# Altium schematic sheet Solar Batter Charger SCH_2.SchDoc("Solar Batter Charger SCH_2")
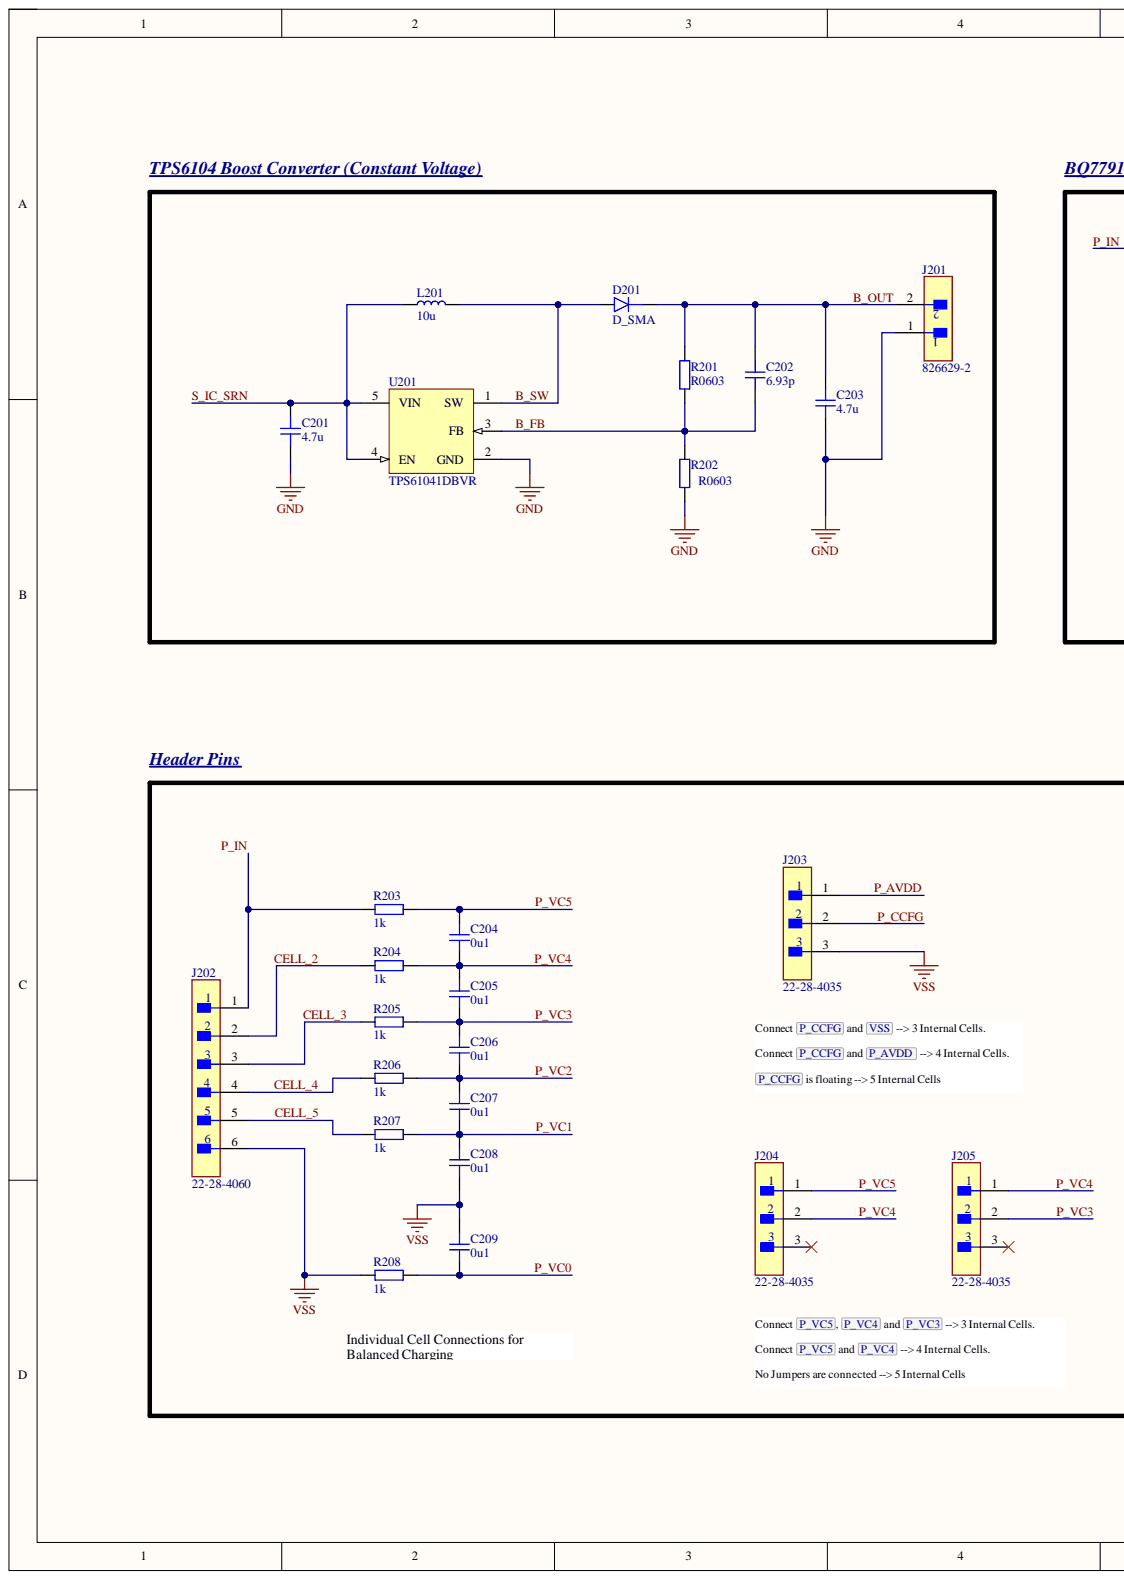
[diagram p0: Solar Batter Charger SCH_2.SchDoc, left]
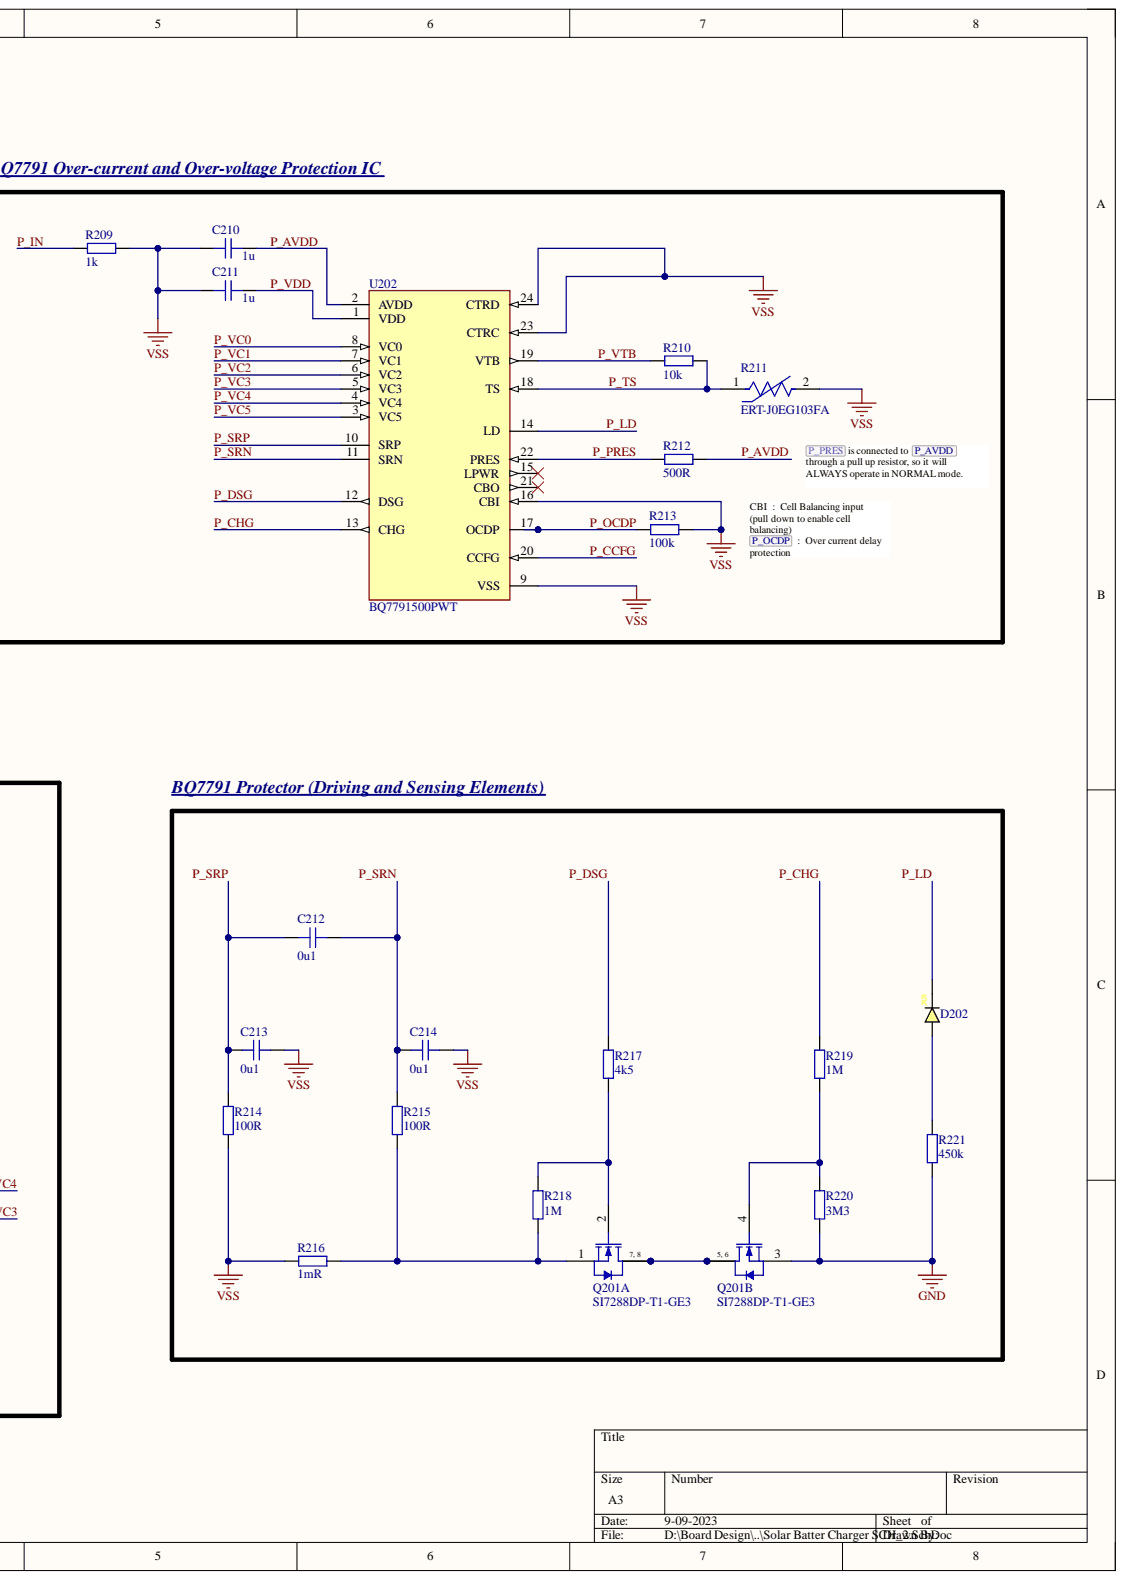
[diagram p1: Solar Batter Charger SCH_2.SchDoc, right]

## Parts
C201  4.7u  [CAP_1210]
C202  6.93p  [CAP_0805]
C203  4.7u  [CAP_1210]
C204  0u1  [CAP_0603]
C205  0u1  [CAP_0603]
C206  0u1  [CAP_0603]
C207  0u1  [CAP_0603]
C208  0u1  [CAP_0603]
C209  0u1  [CAP_0603]
C210  1u  [CAP_0603]
C211  1u  [CAP_0603]
C212  0u1  [CAP_0603]
C213  0u1  [CAP_0603]
C214  0u1  [CAP_0603]
D201  D_SMA  [DO-214AC-2 (SMA)]
D202  YELLOW  [LED_0603_YELLOW]  (value not drawn)
J201  826629-2  [FP-826629-2-MFG]
J202  22-28-4060  [FP-22-28-4060-MFG]
J203  22-28-4035  [FP-22-28-4035-MFG]
J204  22-28-4035  [FP-22-28-4035-MFG]
J205  22-28-4035  [FP-22-28-4035-MFG]
L201  10u  [NRS5020]
Q201  SI7288DP-T1-GE3  [VISH-POWERPAKSO-8_A_V]  (parts A,B)
R201  R0603  [RES_0603]
R202  R0603  [RES_0603]
R203  1k  [RES_0603]
R204  1k  [RES_0603]
R205  1k  [RES_0603]
R206  1k  [RES_0603]
R207  1k  [RES_0603]
R208  1k  [RES_0603]
R209  1k  [RES_0603]
R210  10k  [RES_0402]
R211  ERT-J0EG103FA  [FP-ERTJ0-MFG]
R212  500R  [RES_0402]
R213  100k  [RES_0805]
R214  100R  [RES_0402]
R215  100R  [RES_0402]
R216  1mR  [RES_0603]
R217  4k5  [RES_0805]
R218  1M  [RES_0805]
R219  1M  [RES_0805]
R220  3M3  [RES_0805]
R221  450k  [RES_0805]
U201  TPS61041DBVR  [DBV0005A_M]
U202  BQ7791500PWT  [FP-PW0024A-MFG]

## Nets
GND: C201.1 C203.1 J201.1 Q201.3 R202.1 R220.1 R221.1 U201.2(GND)
VSS: C208.2 C209.1 C210.1 C211.1 C213.2 C214.2 J202.6 J203.3 R208.1 R209.2 R211.2 R213.2 R214.1 R216.2 U202.9(VSS) U202.16(CBI) U202.23(CTRC) U202.24(CTRD)
B_FB: C202.2 R201.1 R202.2 U201.3(FB)
B_OUT: C202.1 C203.2 D201.K J201.2 R201.2
B_SW: D201.A L201.2 U201.1(SW)
CELL_2: J202.2 R204.1
CELL_3: J202.3 R205.1
CELL_4: J202.4 R206.1
CELL_5: J202.5 R207.1
P_AVDD: C210.2 J203.1 R212.2 U202.2(AVDD)
P_CCFG: J203.2 U202.20(CCFG)
P_CHG: R219.2 U202.13(CHG)
P_DSG: R217.2 U202.12(DSG)
P_IN: J202.1 R203.1 R209.1
P_LD: D202.K U202.14(LD)
P_OCDP: R213.1 U202.17(OCDP)
P_PRES: R212.1 U202.22(PRES)
P_SRN: C212.2 C214.1 R215.2 U202.11(SRN)
P_SRP: C212.1 C213.1 R214.2 U202.10(SRP)
P_TS: R210.2 R211.1 U202.18(TS)
P_VC0: C209.2 R208.2 U202.8(VC0)
P_VC1: C207.2 C208.1 R207.2 U202.7(VC1)
P_VC2: C206.2 C207.1 R206.2 U202.6(VC2)
P_VC3: C205.2 C206.1 J205.2 R205.2 U202.5(VC3)
P_VC4: C204.2 C205.1 J204.2 J205.1 R204.2 U202.4(VC4)
P_VC5: C204.1 J204.1 R203.2 U202.3(VC5)
P_VDD: C211.2 U202.1(VDD)
P_VTB: R210.1 U202.19(VTB)
S_IC_SRN: C201.2 L201.1 U201.4(EN) U201.5(VIN)
NetD202_A: D202.A R221.2
NetQ201_1: Q201.1 R215.1 R216.1 R218.1
NetQ201_2: Q201.2 R217.1 R218.2
NetQ201_4: Q201.4 R219.1 R220.2
NetQ201_5: Q201.5 Q201.6 Q201.7 Q201.8

Not connected: J204 3; J205 3; U202 15(LPWR) 21(CBO)

## Library data of the parts
C201 C203 = CAP_1210: Capacitor SMD 1210; Component Kind: Standard; Library Name: Schematic-Lib-PhilsLab.SchLib
C202 = CAP_0805: Capacitor SMD 0805; Component Kind: Standard; Library Name: Schematic-Lib-PhilsLab.SchLib
C204-C214 = CAP_0603: Capacitor SMD 0603; Component Kind: Standard; Library Name: Schematic-Lib-PhilsLab.SchLib
D201 = D_SMA: Diode SMD SMA (DO-214AA); Component Kind: Standard; Library Name: Schematic-Lib-PhilsLab.SchLib
D202 = LED_0603_YELLOW: LED 0603 YELLOW SMD; Component Kind: Standard; Library Name: Schematic-Lib-PhilsLab.SchLib
J201 = CMP-05178-000003-1: CONN HEADER VERT 2POS 2.54MM; Component Kind: Standard; Library Name: Altium Content Vault; RoHS Compliant: Yes
J202 = CMP-04776-000763-1: CONN HEADER VERT 6POS 2.54MM; Connector Type: Male Pin; Contact Resistance: 20mR; Current Rating: 4A; Gender: Male; Max Voltage Rating (AC): 250V; Max Voltage Rating (DC): 250.25kV; Number of Contacts: 6; Color: Black; Component Kind: Standard; Contact Material: Brass; Contact Mating Length: 0.24inch; Contact Plating: Tin; Contact Type: Male Pin; Depth: 2.49mm; Housing Color: Black; Housing Material: Thermoplastic; Length: 15.24mm; Library Name: Altium Content Vault; Max Operating Temperature: 75°C; Min Operating Temperature: 0°C; Mount: Through Hole; Number of Positions: 6; Number of Rows: 1; Orientation: Straight; Package Quantity: 1; Packaging: Bulk; Pitch: 2.54mm; RoHS Compliant: Yes; Series: KK® 42375; Termination: Solder; Voltage Rating: 250V
J203-J205 = CMP-00257-00247547-1: 22-28-4035 BOARD-BOARD Connector HEADER, 3WAY, 1ROW; Series: KK; Pitch Spacing: 2.54mm; No. of Rows: 1;; Manufacturer Lifecycle Status: ACTIVE; NexarPartId: 247547; Connector Type: Male Pin; Contact Resistance: 20mΩ; Current Rating: 4A; Gender: Male; Glow Wire Compliant: Non-compliant; Max Voltage Rating (DC): 250.25kV; Number of Circuits: 3; Plating: Tin; Voltage Rating: 250V; Color: Black; Component Kind: Standard; Contact Material: Brass; Contact Mating Length: 7.493mm; Contact Plating: Gold; Country of Origin: US; Housing Color: Black; Housing Material: Thermoplastic; Lead Free: Lead Free; Library Name: Altium Content Vault; Lifecycle Status: Production; Max Operating Temperature: 75°C; Min Operating Temperature: 0°C; Mount: Through Hole; Number of Contacts: 3; Number of Positions: 3; Number of Rows: 1; Orientation: Vertical; Packaging: Bulk; Pitch: 2.54mm; REACH SVHC: Unknown; RoHS: Compliant; Schedule B: 8536694040; Termination: Through Hole
L201 = NRS5020: NRS5020 SMD Power Inductor Taiyo Yuden; Component Kind: Standard; Manufacturer: Taiyo Yuden; Manufacturer Part Number: NRS5020; Library Name: Schematic-Lib-PhilsLab.SchLib
Q201 = CMP-2000-07062-1: Dual N-Channel (D-S) MOSFET, 40 V, 20 A, -55 to 150 degC, 8-Pin POWERPAKSO-8, RoHS, Tape and Reel; Manufacturer: Vishay; Current - Continuous Drain (Id) @ 25°C: 20A; Drain to Source Voltage (Vdss): 40V; FET Feature: Standard; FET Type: 2N-Channel(Dual); Gate Charge (Qg) @ Vgs: 15nC@10V; Input Capacitance (Ciss) @ Vds: 565pF@20V; Online Catalog: N-ChannelStandardFETs; Other Names: SI7288DP-T1-GE3DKR; PCN Assembly/Origin: MultipleFabracationChanges09/Jul/2014; Power - Max: 15.6W; Rds On (Max) @ Id, Vgs: 19mOhm@10A,10V; Vgs(th) (Max) @ Id: 2.8V@250µA; Category: DiscreteSemiconductorProducts; Component Kind: Standard; Datasheet URL: http://www.vishay.com/docs/65366/si7288dp.pdf; Datasheet Version: Rev. A, 10/2009; Family: FETs-Arrays; Library Name: Altium Content Vault; Manufacturer URL: http://www.vishay.com/; Mounting Technology: SurfaceMount; Mounting Type: SurfaceMount; Package / Case: PowerPAK®SO-8Dual; Package Description: 8-Pin Surface Mount Device, Body 6.15 x 5.155 mm, Pitch 1.27 mm; Package Reference: POWERPAKSO-8; Packaging: Digi-Reel®; Series: TrenchFET®; Standard Package: 1; Supplier Device Package: PowerPAK®SO-8Dual
R201-R209 R216 = RES_0603: Resistor SMD 0603; Component Kind: Standard; Library Name: Schematic-Lib-PhilsLab.SchLib
R210 R212 R214 R215 = RES_0402: Resistor SMD 0402; Component Kind: Standard; Library Name: Schematic-Lib-PhilsLab.SchLib
R211 = CMP-2002-01179-2: THERMISTOR NTC 10KOHM 3380K 0402; Accuracy: 1%; Max Power Dissipation: 66mW; Resistance: 10kR; Case Code (Imperial): 0402; Case Code (Metric): 1005; Case/Package: 0402; Component Kind: Standard; Contact Plating: Tin; Depth: 0.5mm; Height: 0.5mm; Lead Free: Lead Free; Length: 1mm; Library Name: Altium Content Vault; Max Operating Temperature: 125°C; Min Operating Temperature: -40°C; Mount: Surface Mount; Number of Pins: 2; Package Quantity: 1; Packaging: Tape and Reel; Power Rating: 66mW; Radiation Hardening: No; REACH SVHC: No SVHC; Resistance Tolerance: 1%; RoHS Compliant: Yes; Series: ERT-J; Termination: SMD/SMT; Tolerance: 1%; Width: 500um
R213 R217-R221 = RES_0805: Resistor SMD 0805; Component Kind: Standard; Library Name: Schematic-Lib-PhilsLab.SchLib
U201 = CMP-0388-00116-2: 28 V, 250 mA Switch Boost Converter for LCD and White LED Applications, 1.8 to 6 V, -40 to 85 degC, 5-pin SOT23 (DBV5), Green (RoHS & no Sb/Br); Manufacturer: Texas Instruments; Dimming: TRUE; Iout(Max)(A): 0.05; Iq(Typ)(mA): 0.028; Rating: Catalog; SubFamily: White LED Driver; Switching Frequency(Max)(kHz): 1000; Vin(Max)(V): 6; Vin(Min)(V): 1.8; Code_JEDEC: MO-178; Component Kind: Standard; ComponentLink1Description: Manufacturer URL; ComponentLink1URL: http://www.ti.com/; ComponentLink2Description: Datasheet; ComponentLink2URL: http://www.ti.com/general/docs/lit/getliterature.tsp?genericPartNumber=TPS61041&fileType=pdf; ComponentLink3Description: Package Specification; ComponentLink3URL: http://www.ti.com/litv/pdf/mpds018i; DatasheetVersion: SLVS413F; Eco-Plan: Green (RoHS & no Sb/Br); Library Name: Altium Content Vault; Mounting Technology: Surface Mount; PackageDescription: 5-Pin Plastic Small Outline, Body 1.60 Ãƒâ€” 2.90 mm, Pitch 0.95 mm; PackageReference: DBV0005A; PackageVersion: 4073253-4/K; PartNumber: TPS61041DBVR; Topology: Boost
U202 = CMP-04911-000012-1: 3-SERIES TO 5-SERIES STACKABLE U; Manufacturer: Texas Instruments; Max Supply Voltage: 25V; Min Supply Voltage: 3V; Nominal Supply Current: 8-48uA; Number Of Cells: 5; Output Current: 50mA; Output Voltage: 0-25V; Standoff Height: 0.05mm; Automotive: No; Category: IC; Component Kind: Standard; ComponentLink2Description: Datasheet; ComponentLink2URL: http://www.ti.com/lit/ds/symlink/bq77915.pdf; Device Class L1: Integrated Circuits (ICs); Device Class L2: Power Management ICs; Device Class L3: Battery Management; Digikey Description: 3-SERIES TO 5-SERIES STACKABLE U; Height: 1.2mm; Lead Free: Yes; Library Name: Altium Content Vault; Manufacturer Part Number: BQ7791500PWT; Octopart: https://octopart.com/bq7791500pwt-texas+instruments-90752675; Package: PW0024A; RoHS: TRUE; Temperature: -40°C to +85°C; Temperature Range High: +85°C; Temperature Range Low: -40°C
